# Altium schematic sheet Base_USB_Camera.SchDoc
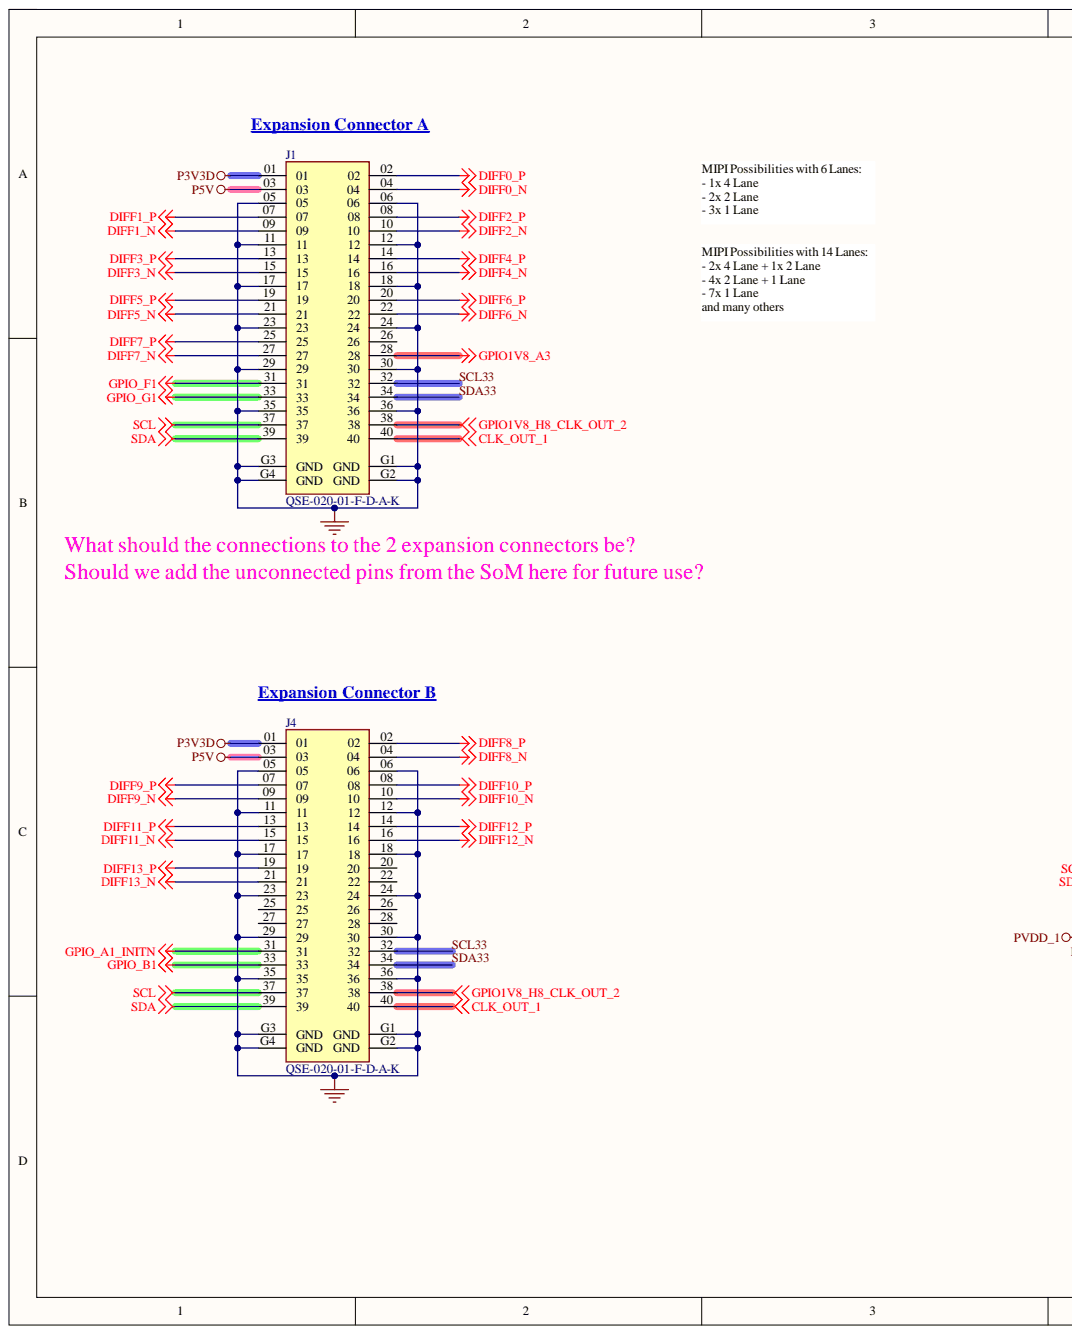
[diagram p0: Base_USB_Camera.SchDoc, left]
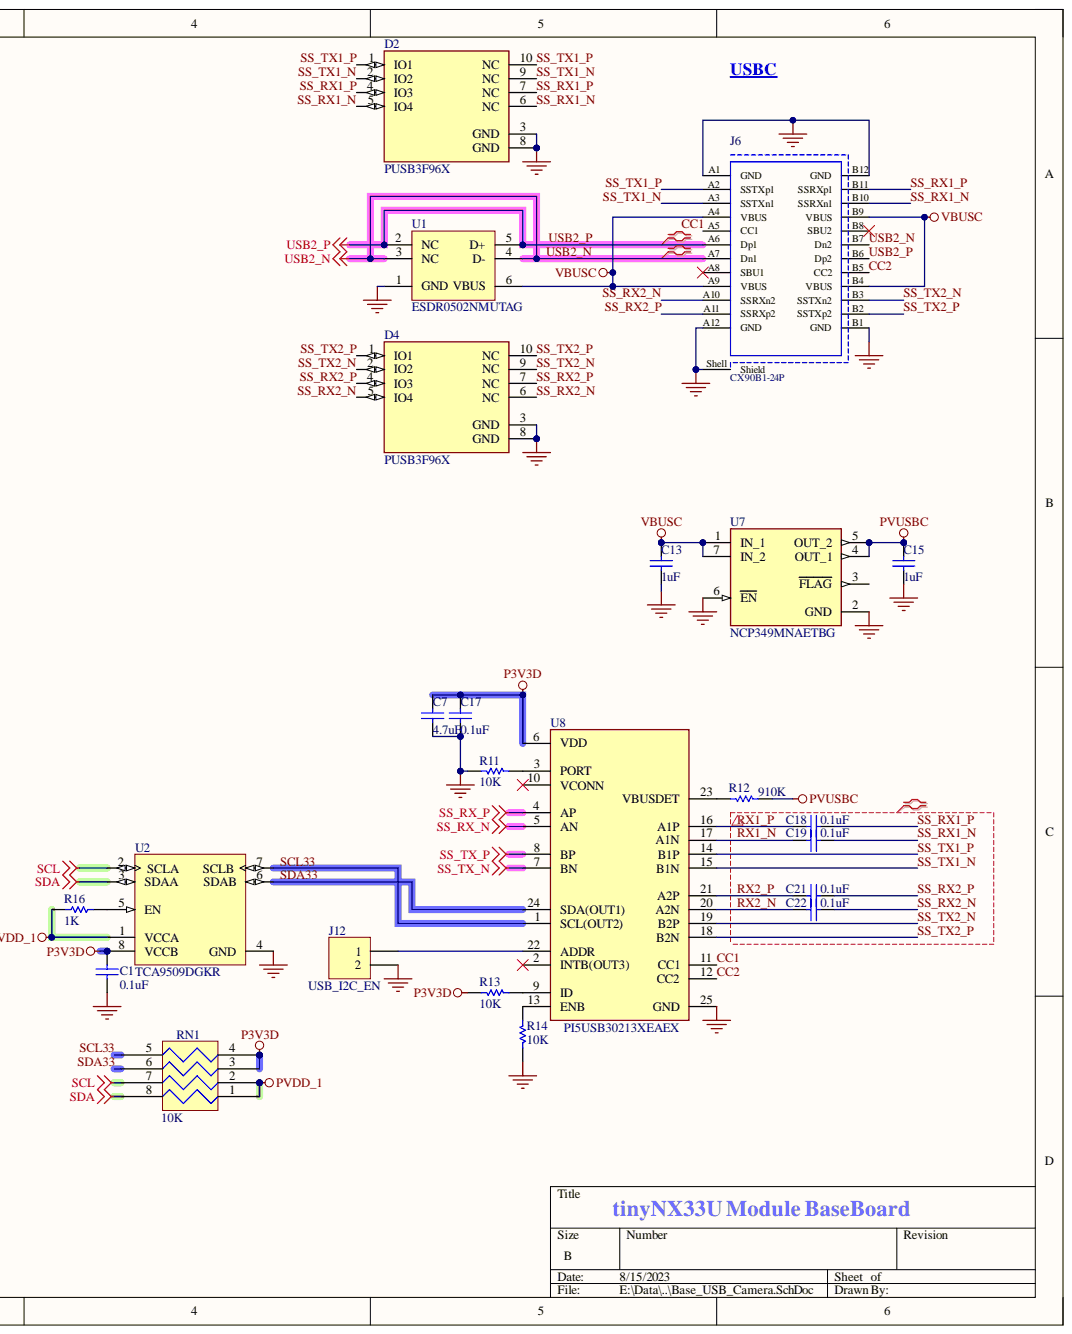
[diagram p1: Base_USB_Camera.SchDoc, right]

## Parts
C1  0.1uF  [CAPC1005X55USR]
C7  4.7uF  [CAPC1005X55USR]
C13  1uF  [CAPC1005X55USR]
C15  1uF  [CAPC1005X55USR]
C17  0.1uF  [CAPC1005X55USR]
C18  0.1uF  [CAPC1005X55USR]
C19  0.1uF  [CAPC1005X55USR]
C21  0.1uF  [CAPC1005X55USR]
C22  0.1uF  [CAPC1005X55USR]
D2  PUSB3F96X  [DFN2510A-10]
D4  PUSB3F96X  [DFN2510A-10]
J1  QSE-020-01-F-D-A-K  [SAMTEC_QSE-020-01-XXX-D-A-L]
J4  QSE-020-01-F-D-A-K  [SAMTEC_QSE-020-01-XXX-D-A-L]
J6  CX90B1-24P  [HRS_CX90B1-24P]
J12  Content: USB_I2C_EN  [HDR2X1_MALE_2.54MM]  (hidden comment: Header 2X1_2.54MM)
R11  10K  [RESC1005X40USR]
R12  910K  [RESC1005X40USR]
R13  10K  [RESC1005X40USR]
R14  10K  [RESC1005X40USR]
R16  1K  [RESC1005X40USR]
RN1  10K  [RESCAXS50P100X200X45-8NA]
U1  ESDR0502NMUTAG  [ONSC-UDFN-6-517AA-01_V]
U2  TCA9509DGKR  [DGK0008A_L]
U7  NCP349MNAETBG  [SON50P200X160X100-7N-D]
U8  PI5USB30213XEAEX  [QFN40P400X200X50-25N]  (value not drawn)

## Nets
CLK_OUT_1: J1.40 J4.40
DIFF0_N: J1.04
DIFF0_P: J1.02
DIFF1_N: J1.09
DIFF1_P: J1.07
DIFF2_N: J1.10
DIFF2_P: J1.08
DIFF3_N: J1.15
DIFF3_P: J1.13
DIFF4_N: J1.16
DIFF4_P: J1.14
DIFF5_N: J1.21
DIFF5_P: J1.19
DIFF6_N: J1.22
DIFF6_P: J1.20
DIFF7_N: J1.27
DIFF7_P: J1.25
DIFF8_N: J4.04
DIFF8_P: J4.02
DIFF9_N: J4.09
DIFF9_P: J4.07
DIFF10_N: J4.10
DIFF10_P: J4.08
DIFF11_N: J4.15
DIFF11_P: J4.13
DIFF12_N: J4.16
DIFF12_P: J4.14
DIFF13_N: J4.21
DIFF13_P: J4.19
GND: C1.1 C7.1 C13.1 C15.1 C17.1 D2.3(GND) D2.8(GND) D4.3(GND) D4.8(GND) J1.05 J1.06 J1.11 J1.12 J1.17 J1.18 J1.23 J1.24 J1.29 J1.30 J1.35 J1.36 J1.G1(GND) J1.G2(GND) J1.G3(GND) J1.G4(GND) J4.05 J4.06 J4.11 J4.12 J4.17 J4.18 J4.23 J4.24 J4.29 J4.30 J4.35 J4.36 J4.G1(GND) J4.G2(GND) J4.G3(GND) J4.G4(GND) J6.A1(GND) J6.A12(GND) J6.B1(GND) J6.B12(GND) J6.Shell(Shield) J12.2 R11.1 R14.2 U1.1(GND) U2.4(GND) U7.2(GND) U7.6(EN) U8.25(GND)
GPIO1V8_A3: J1.28
GPIO1V8_H8_CLK_OUT_2: J1.38 J4.38
GPIO_A1_INITN: J4.31
GPIO_B1: J4.33
GPIO_F1: J1.31
GPIO_G1: J1.33
P3V3D: C1.2 C7.2 C17.2 J1.01 J4.01 R13.1 RN1.3 RN1.4 U2.8(VCCB) U8.6(VDD)
P5V: J1.03 J4.03
PVDD_1: R16.1 RN1.1 RN1.2 U2.1(VCCA)
PVUSBC: C15.2 R12.2 U7.4(OUT_1) U7.5(OUT_2)
SCL: J1.37 J4.37 RN1.7 U2.2(SCLA)
SDA: J1.39 J4.39 RN1.8 U2.3(SDAA)
SS_RX_N: U8.5(AN)
SS_RX_P: U8.4(AP)
SS_TX_N: U8.7(BN)
SS_TX_P: U8.8(BP)
VBUSC: C13.2 J6.A4(VBUS) J6.A9(VBUS) J6.B4(VBUS) J6.B9(VBUS) U1.6(VBUS) U7.1(IN_1) U7.7(IN_2)
CC1: J6.A5(CC1) U8.11(CC1)
CC2: J6.B5(CC2) U8.12(CC2)
RX1_N: C19.1 U8.17(A1N)
RX1_P: C18.1 U8.16(A1P)
RX2_N: C22.1 U8.20(A2N)
RX2_P: C21.1 U8.21(A2P)
SCL33: J1.32 J4.32 RN1.5 U2.7(SCLB) U8.1(SCL(OUT2))
SDA33: J1.34 J4.34 RN1.6 U2.6(SDAB) U8.24(SDA(OUT1))
SS_RX1_N: C19.2 D2.5(IO4) D2.6(NC) J6.B10(SSRXn1)
SS_RX1_P: C18.2 D2.4(IO3) D2.7(NC) J6.B11(SSRXp1)
SS_RX2_N: C22.2 D4.5(IO4) D4.6(NC) J6.A10(SSRXn2)
SS_RX2_P: C21.2 D4.4(IO3) D4.7(NC) J6.A11(SSRXp2)
SS_TX1_N: D2.2(IO2) D2.9(NC) J6.A3(SSTXn1) U8.15(B1N)
SS_TX1_P: D2.1(IO1) D2.10(NC) J6.A2(SSTXp1) U8.14(B1P)
SS_TX2_N: D4.2(IO2) D4.9(NC) J6.B3(SSTXn2) U8.19(B2P)
SS_TX2_P: D4.1(IO1) D4.10(NC) J6.B2(SSTXp2) U8.18(B2N)
USB2_N: J6.A7(Dn1) J6.B7(Dn2) U1.3(NC) U1.4(D-)
USB2_P: J6.A6(Dp1) J6.B6(Dp2) U1.2(NC) U1.5(D+)
NetJ12_1: J12.1 U8.22(ADDR)
NetR11_2: R11.2 U8.3(PORT)
NetR12_1: R12.1 U8.23(VBUSDET)
NetR13_2: R13.2 U8.9(ID)
NetR14_1: R14.1 U8.13(ENB)
NetR16_2: R16.2 U2.5(EN)

Not connected: J1 26; J4 20 22 25 26 27 28; J6 A8(SBU1) B8(SBU2); U7 3(FLAG); U8 2(INTB(OUT3)) 10(VCONN)

## Library data of the parts
C1 C17-C19 C21 C22 = CAP CER 0.1UF 16V X7R 0402: Manufacturer: TDK Corporation; Manufacturer Part Number: CGA2B1X7R1C104K050BC; Component Kind: Standard; Description: CAP CER 0.1UF 16V X7R 0402; Height: 0.55mm; Library Name: NX Module baseboard.SCHLIB; Package: 0402; Supplier 1: Digi-Key; Supplier Part Number 1: 445-5613-2-ND; value: 0.1uF
C7 = CAP CER 4.7UF 10V X5R 0402: Manufacturer: TDK Corporation; Manufacturer Part Number: C1005X5R1A475M050BC; Component Kind: Standard; ComponentLink1Description: New link; Description: CAP CER 4.7UF 10V X5R 0402; Height: 0.55mm; Library Name: NX Module baseboard.SCHLIB; Package: 0402; Supplier 1: Digi-Key; Supplier Part Number 1: 445-8023-1-ND; value: 4.7uF
C13 C15 = CAP CER 1UF 25V X5R 0402: Manufacturer: Murata Electronics; Manufacturer Part Number: GRM155R61E105MA12D; Part #: E-CAP-042; Component Kind: Standard; ComponentLink1Description: New link; Description: CAP CER 1UF 25V X5R 0402; Height: 0.55mm; Package: 0402; Supplier 1: Digi-Key; Supplier Part Number 1: 490-10018-1-ND; value: 1uF; Library Name: Tiger_Ti180.SCHLIB
D2 D4 = PUSB3F96X: TVS DIODE 5.5VWM 4.6VC DFN2510A; Manufacturer: Nexperia USA Inc.; Interface: USB; Component Kind: Standard; Manufacturer Part Number: PUSB3F96X; Supplier 1: Digi-Key; Supplier Part Number 1: 1727-2030-1-ND; Library Name: Tiger_Ti180.SCHLIB
J1 J4 = QSE-020-01-F-D-A-K: Q Strip® High-Speed Ground Plane Header, 0.80mm Pitch; Manufacturer: Samtec; Manufacturer Part Number: QTE-020-01-F-D-A; Gender: Male; Mated Stacking Heights: 5mm; Number of Contacts: 40; Pitch: 0.031inch; Category: Board to Board Connectors; Component Kind: Standard; ComponentLink1Description: https://datasheet.ciiva.com/6924/qte-xxx-xx-x-d-xxx-mkt-6924765.pdf?src-supplier=Digi-Key; ComponentLink1URL: https://datasheet.ciiva.com/6924/qte-xxx-xx-x-d-xxx-mkt-6924765.pdf?src-supplier=Digi-Key; Contact Plating: Gold; Library Name: NX Module baseboard.SchLib; Mounting Technology: Surface Mount; Number of Positions: 40; Number of Rows: 2; Package Quantity: 1; Packaging: Tray; Pricing: 1=9.48000, 10=8.53000, 25=8.03560, 40=7.82950, 96=7.62344, 288=6.79931, 480=6.38725, 960=5.64550 (USD); RoHS: Yes; RoHS Compliant: Yes; Series: QStrip® QTE; Stock: 1329; Supplier 1: Digi-Key; Supplier Part Number 1: SAM8846-ND; Termination: Solder
J6 = USB 3.1_HRS_CX90B1-24P: CONN RCP USB3.1 TYPEC 24P SMD RA; Manufacturer: Hirose Electric Co Ltd; Number of Contacts: 24; Component Kind: Standard; ComponentLink1Description: https://www.mouser.com/ds/2/445/632723x00011-782157.pdf?src-supplier=Mouser; ComponentLink1URL: https://www.mouser.com/ds/2/445/632723x00011-782157.pdf?src-supplier=Mouser; ComponentLink2Description: https://katalog.we-online.de/em/datasheet/632723x00011.pdf?src-supplier=Digi-Key; ComponentLink2URL: https://katalog.we-online.de/em/datasheet/632723x00011.pdf?src-supplier=Digi-Key; Manufacturer Part Number: CX90B1-24P; Supplier 1: Digi-Key; Supplier Part Number 1: H125778CT-ND; Library Name: Tiger_Ti180.SCHLIB
J12 = Header 2X1_2.54MM: Conn Header Vert 2POS 2.54MM; Manufacturer: Sullins; Manufacturer Part Number: PRPC002SAAN-RC; Number of Positions: 2; Pitch: 0.1inch; Category: Connectors; Color: Black; Component Kind: Standard; ComponentLink1Description: https://datasheet.ciiva.com/741/xrxczzzsxxn-rc-st-11635-b-741243.pdf?src-supplier=Digi-Key; ComponentLink1URL: https://datasheet.ciiva.com/741/xrxczzzsxxn-rc-st-11635-b-741243.pdf?src-supplier=Digi-Key; Contact Mating Length: 0.23inch; Contact Plating: Gold; Contact Type: Male Pin; Library Name: NX Module baseboard.SCHLIB; Mounting Technology: Through Hole; Number of Rows: 1; Package Quantity: 1; Packaging: Bulk; Pricing: 1=0.08000, 10=0.07300, 25=0.05400, 50=0.04640, 100=0.04490, 250=0.03872, 500=0.03716 (USD); RoHS: Yes; RoHS Compliant: Yes; Series: -; Stock: 31528; Supplier 1: Digi-Key; Supplier Part Number 1: S1011EC-02-ND; Termination: Solder
R11 R13 R14 = RES SMD 10K OHM 1% 1/16W 0402: Manufacturer: Samsung Electro-Mechanics; Manufacturer Part Number: RC1005F103CS; Component Kind: Standard; Description: RES SMD 10K OHM 1% 1/16W 0402; Height: 0.4mm; Library Name: NX Module baseboard.SCHLIB; Package: 0402; Supplier 1: Digi-Key; Supplier Part Number 1: 1276-3431-2-ND; value: 10K
R12 = RES 910K OHM 1% 1/16W 0402: Manufacturer: YAGEO; Manufacturer Part Number: RC0402FR-07910KL; Component Kind: Standard; Description: RES 910K OHM 1% 1/16W 0402; Height: 0.4mm; Library Name: NX Module baseboard.SCHLIB; Package: 0402; Supplier 1: Digi-Key; Supplier Part Number 1: YAG3257CT-ND; value: 910K
R16 = RES 1K OHM 1% 1/16W 0402: RES SMD 1K OHM 1% 1/16W 0402; Manufacturer: YAGEO; Manufacturer Part Number: RC0402FR-071KL; Component Kind: Standard; Height: 0.4mm; Package: 0402; Supplier 1: Digi-Key; Supplier Part Number 1: 311-1.00KLRCT-ND; value: 1K; Library Name: Tiger_Ti180.SCHLIB
RN1 = RES ARRAY 4 RES 47K OHM 0804: Res Thick Film Array 10K Ohm 5% ±200ppm/°C ISOL 8-Pin 0804(4 X 0402) Convex SMD Automotive T/R; Manufacturer: Panasonic; Manufacturer Part Number: EXB-28V103JX; Circuit Type: Isolated; Number of Contacts: 8; Number of Elements: 4; Power Dissipation: 63mW; Power Per Element: 62.5mW; Voltage Rating: 50V; Voltage Rating (DC): 100V; Case Code (Imperial): 0402; Case Code (Metric): 1005; Case/Package: 0804; Category: Resistor Arrays; Component Kind: Standard; ComponentLink1Description: https://datasheet.ciiva.com/46387/1480003-46387949.pdf?src-supplier=Element14; ComponentLink1URL: https://datasheet.ciiva.com/46387/1480003-46387949.pdf?src-supplier=Element14; ComponentLink2Description: https://datasheet.ciiva.com/46376/1480003-46376265.pdf?src-supplier=Element14; ComponentLink2URL: https://datasheet.ciiva.com/46376/1480003-46376265.pdf?src-supplier=Element14; ComponentLink3Description: https://datasheet.ciiva.com/28418/1790599-28418558.pdf?src-supplier=Element14; ComponentLink3URL: https://datasheet.ciiva.com/28418/1790599-28418558.pdf?src-supplier=Element14; ComponentLink4Description: https://datasheet.ciiva.com/28636/1790599-28636885.pdf?src-supplier=Element14; ComponentLink4URL: https://datasheet.ciiva.com/28636/1790599-28636885.pdf?src-supplier=Element14; ComponentLink5Description: https://datasheet.ciiva.com/30209/aoc0000ce1-30209125.pdf?src-supplier=Onlinecomponents.com; ComponentLink5URL: https://datasheet.ciiva.com/30209/aoc0000ce1-30209125.pdf?src-supplier=Onlinecomponents.com; Depth: 1mm; Height: 0.35mm; Lead Free: Lead Free; Length: 2mm; Library Name: NX Module.SCHLIB; Max Operating Temperature: 125°C; Military Standard: Not; Min Operating Temperature: -55°C; Mounting Technology: Surface Mount; Number of Resistors: 4; Package Quantity: 1; Packaging: Tape and Reel; Pins: 8; Pricing: 1=0.10000, 10=0.08100, 25=0.05640, 50=0.04380, 100=0.03130, 250=0.02444, 500=0.01942, 1000=0.01378, 5000=0.01065 (USD); Radiation Hardening: No; Reach SVHC Compliant: Unknown; Resistance Tolerance: 5%; RoHS: Yes; RoHS Compliant: Yes; Series: EXB; Stock: 1570078; Supplier 1: Digi-Key; Supplier Part Number 1: Y7103CT-ND; Technology: THICK FILM; Temperature Coefficient: 200ppm/°C; Terminal Pitch: 0.5mm; Termination: Convex; Tolerance: 5%; Value: 10K; Width: 1mm
U1 = ONSC-ESDR0502N-UDFN-6-517AA-01: TVS DIODE 5.5VWM 6UDFN; Manufacturer: ON Semiconductor; Component Kind: Standard; ComponentLink1Description: Manufacturer URL; ComponentLink1URL: http://www.onsemi.com/; ComponentLink2Description: Datasheet; ComponentLink2URL: http://www.onsemi.cn/pub_link/Collateral/ESDR0502N-D.PDF; ComponentLink3Description: Package Specification; ComponentLink3URL: http://www.onsemi.com/pub_link/Collateral/517AA.PDF; Manufacturer Part Number: ESDR0502NMUTAG; Supplier 1: Mouser; Supplier Part Number 1: 863-ESDR0502NMUTAG; Library Name: Tiger_Ti180.SCHLIB
U2 = CMP-0314-00313-2: Level Translating I2C Bus Repeater, 2.7 to 5.5 V, -40 to 85 degC, 8-pin MSOP (DGK), Green (RoHS & no Sb/Br); Manufacturer: Texas Instruments; Enable Pin: Yes; fSCLK(Max)(MHz): 0.4; Master Side I2C Bus Capacitance Supported(pF): 400; SubFamily: I2C Hub, Buffer, Repeater; VCC(Max)(V): 5.5; VCC(Min)(V): 2.7; Code_JEDEC: MO-187-AA; Component Kind: Standard; ComponentLink1Description: Datasheet; ComponentLink1URL: http://www.ti.com/general/docs/lit/getliterature.tsp?genericPartNumber=TCA9509&fileType=pdf; ComponentLink2Description: Package Specification; ComponentLink2URL: http://www.ti.com/litv/pdf/msoi002j; DatasheetVersion: SCPS225B; Eco-Plan: Green (RoHS & no Sb/Br); Library Name: Altium Content Vault; Max Frequency(kHz): 400; Mounting Technology: Surface Mount; Open Drain I/O Type: Yes; PackageDescription: 8-Pin Thin Small Outline Package 3 x 3 mm Body ,0.65 mm Pitch; PackageReference: DGK0008A; PackageVersion: revE, May-2006; PartNumber: TCA9509DGKR; Slave Side I2C Bus Capacitance Supported(pF): 400; Vcc range(V): 2.7 to 5.5
U7 = NCP349MNAETBG: IC CTLR OVP 28V W/NMOS 6-DFN; Manufacturer: ON Semiconductor; Min Supply Voltage: 1.2V; Operating Supply Current: 70uA; Case/Package: DFN; Category: Power Management ICs; Component Kind: Standard; ComponentLink1Description: https://datasheet.ciiva.com/1967/ncp349-d-1967888.pdf?src-supplier=Avnet; ComponentLink1URL: https://datasheet.ciiva.com/1967/ncp349-d-1967888.pdf?src-supplier=Avnet; ComponentLink2Description: https://datasheet.ciiva.com/6602/ncp349-d-6602421.pdf?src-supplier=Digi-Key; ComponentLink2URL: https://datasheet.ciiva.com/6602/ncp349-d-6602421.pdf?src-supplier=Digi-Key; ComponentLink3Description: https://datasheet.ciiva.com/6602/ncp349-d-6602425.pdf?src-supplier=Digi-Key; ComponentLink3URL: https://datasheet.ciiva.com/6602/ncp349-d-6602425.pdf?src-supplier=Digi-Key; Halogen Free: Halogen Free; Height: 0.95mm; Lead Free: Lead Free; Length: 2mm; Manufacturer Part Number: NCP349MNAETBG; Max Operating Temperature: 85°C; Max Supply Voltage: 28V; Min Operating Temperature: -40°C; Mount: Surface Mount; Number of Pins: 6; Package Quantity: 3000; Packaging: Tape and Reel; Pricing 1: 1=0.96000, 10=0.84700, 25=0.79520, 100=0.64900, 250=0.60284, 500=0.51304, 1000=0.41043 (USD); Reach SVHC Compliant: Yes; RoHS: Yes; RoHS Compliant: Yes; Series: -; Stock 1: 1255; Supplier 1: Digi-Key; Supplier Part Number 1: NCP349MNAETBGOSCT-ND; Weight: 0.000744oz; Width: 1.6mm; Library Name: Tiger_Ti180.SCHLIB
U8 = PI5USB30213XEAEX: USB Power SW DIST Single 2.7V to 5.5V 1.5A/3A 24-Pin TQFN T/R; Manufacturer: Diodes; Category: Power Management ICs; Component Kind: Standard; ComponentLink1Description: https://media.digikey.com/pdf/Data%20Sheets/Pericom%20PDFs/PI5USB30213_Web.pdf?src-supplier=Digi-Key; ComponentLink1URL: https://media.digikey.com/pdf/Data%20Sheets/Pericom%20PDFs/PI5USB30213_Web.pdf?src-supplier=Digi-Key; Library Name: NX Module baseboard.SCHLIB; Manufacturer Part Number: PI5USB30213XEAEX; Pricing: 1=1.92000, 10=1.72200, 25=1.62480, 100=1.38450, 250=1.30000, 500=1.13750, 1000=0.94250 (USD); RoHS: Yes; RoHS Compliant: Yes; Stock: 3456; Supplier 1: Digi-Key; Supplier Part Number 1: PI5USB30213XEAEXCT-ND
